# Altium schematic sheet Twin-T Notch Filter.SchDoc("Twin-T Notch Filter")
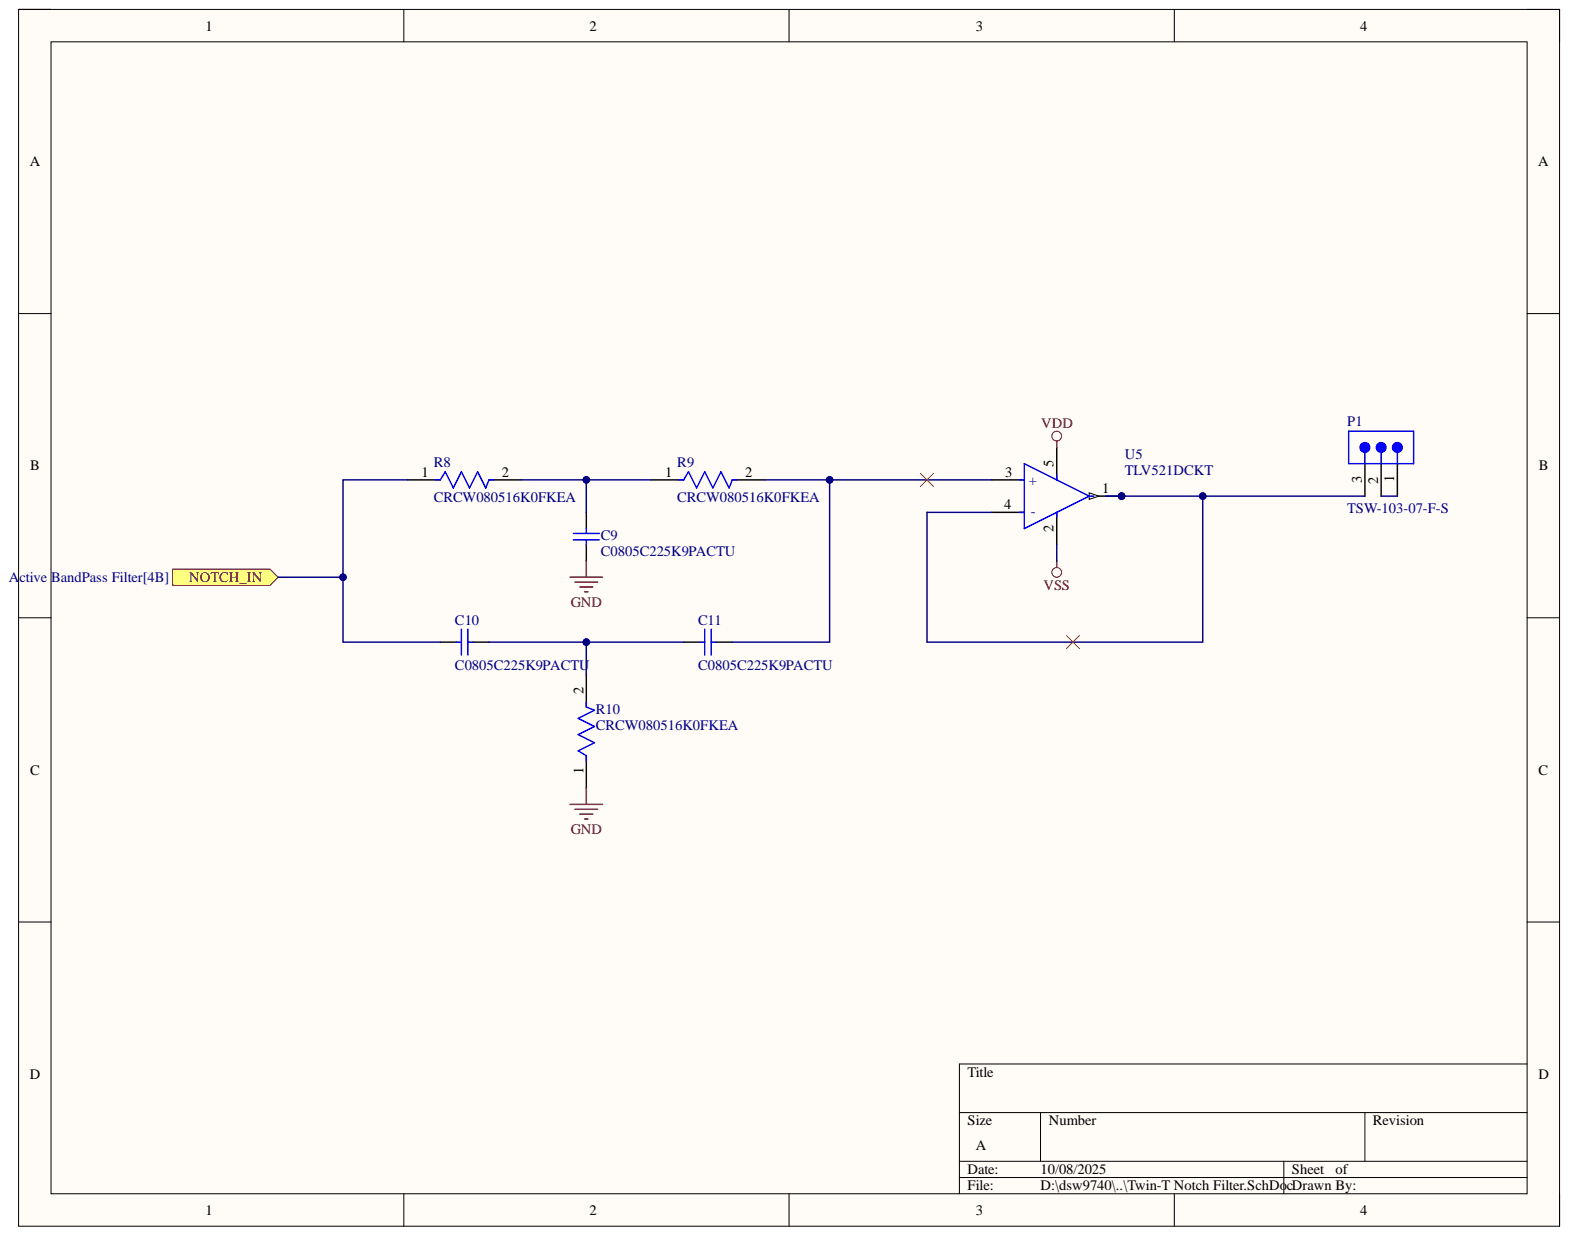
[diagram p0: Twin-T Notch Filter.SchDoc]

## Parts
C9  C0805C225K9PACTU  [CAPC2013X145X50ML20T25]
C10  C0805C225K9PACTU  [CAPC2013X145X50ML20T25]
C11  C0805C225K9PACTU  [CAPC2013X145X50ML20T25]
P1  TSW-103-07-F-S  [SMTC-TSW-103-07-X-S]
R8  CRCW080516K0FKEA  [FP-CRCW0805-e3-IPC_C]
R9  CRCW080516K0FKEA  [FP-CRCW0805-e3-IPC_C]
R10  CRCW080516K0FKEA  [FP-CRCW0805-e3-IPC_C]
U5  TLV521DCKT  [FP-DCK0005A-MFG]

## Ports
NOTCH_IN

## Nets
GND: C9.1 R10.1
VDD: U5.5
VSS: U5.2
NOTCH_IN: C10.1 R8.1 ; port NOTCH_IN
NetC9_2: C9.2 R8.2 R9.1
NetC10_2: C10.2 C11.1 R10.2
NetC11_2: C11.2 R9.2 U5.3(+)
NetP1_1: P1.1 P1.2
NetP1_3: P1.3 U5.1 U5.4(-)

## Library data of the parts
C9-C11 = CMP-1036-04819-2: Manufacturer: Kemet; Component Kind: Standard; Library Name: Altium Content Vault; Part Number: C0805C225K9PACTU
P1 = CMP-1024-00331-1: 0.025" SQ Post Header, Through-hole, Vertical, -55 to 125 degC, 2.54 mm Pitch, 3-Pin, Male, RoHS; Manufacturer: Samtec; Pitch: 2.54 mm; Component Kind: Standard; ComponentLink1Description: Manufacturer URL; ComponentLink1URL: http://www.samtec.com; ComponentLink2Description: Datasheet; ComponentLink2URL: http://www.samtec.com/documents/webfiles/pdf/TSW_TH.PDF; ComponentLink3Description: Package Specification; ComponentLink3URL: http://www.samtec.com/documents/webfiles/cpdf/TSW-XXX-XX-XXX-X-XX-XXX-MKT.pdf; DatasheetVersion: F-214; Library Name: Altium Content Vault; Mounting Technology: Through-hole; Orientation: Vertical; PackageDescription: .025[0.64] SQ. Post Terminal Strip Assembly; PackageReference: TSW-103-07-X-S; PackageVersion: DM, 8/1988; PartNumber: TSW-103-07-F-S; RoHS: True; Temperature Range: -55 to 125 degC
R8-R10 = CMP-2001-04979-2: RES Thick Film, 16kΩ, 1%, 0.125W, 100ppm/°C, 0805; Max Power Dissipation: 125mW; Resistance: 16kR; Voltage Rating: 150V; Case Code (Imperial): 0805; Case Code (Metric): 2012; Case/Package: 0805; Component Kind: Standard; Composition: Thick Film; Contact Plating: Tin; Depth: 1.25mm; Features: Automotive AEC-Q200; Height: 0.45mm; Lead Free: Lead Free; Length: 2mm; Library Name: Altium Content Vault; Max Operating Temperature: 155°C; Military Standard: Not; Min Operating Temperature: -55°C; Mount: Surface Mount; Number of Pins: 2; Number of Terminations: 2; Package Quantity: 10; Packaging: Tape and Reel; Power Rating: 125mW; Radiation Hardening: No; Temperature Coefficient: 100ppm/°C; Termination: SMD/SMT; Tolerance: 1%; Voltage Rating (DC): 150V; Weight: 0.000194oz; Width: 1.25mm
U5 = CMP-04895-000072-1: IC CMOS 1 CIRCUIT SC70-5; Bandwidth: 6kHz; Input Bias Current: 1pA; Input Offset Voltage (Vos): 100uV; Number of Amplifiers: 1; Operating Supply Current: 350nA; Output Current per Channel: 12mA; Slew Rate: 2.5V/µs; Case/Package: SC; Component Kind: Standard; Gain Bandwidth Product: 6kHz; Lead Free: Lead Free; Library Name: Altium Content Vault; Max Operating Temperature: 125°C; Min Operating Temperature: -40°C; Mount: Surface Mount; Number of Channels: 1; Number of Circuits: 1; Number of Pins: 5; Packaging: Cut Tape; REACH SVHC: No SVHC
